# Altium schematic sheet JTAG.SchDoc
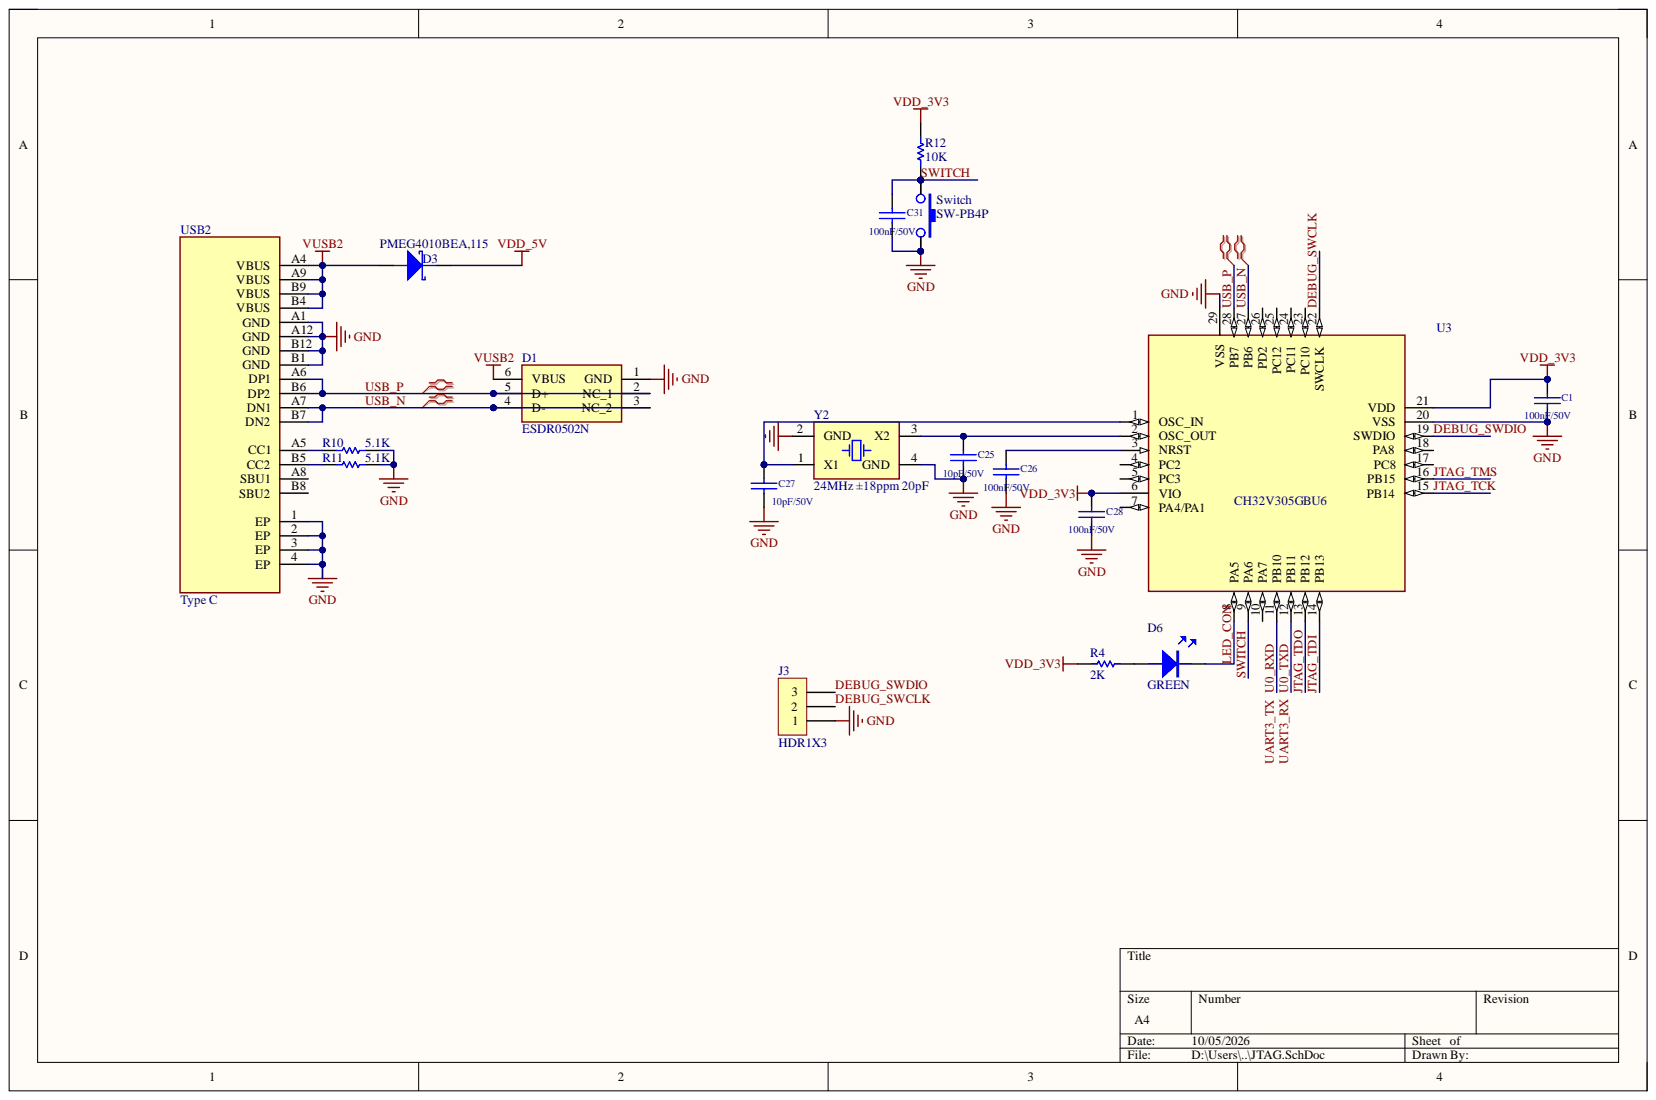
[diagram p0: JTAG.SchDoc]

## Parts
C1  100nF/50V  [C0402]
C25  10pF/50V  [C0402]
C26  100nF/50V  [C0402]
C27  10pF/50V  [C0402]
C28  100nF/50V  [C0402]
C31  100nF/50V  [C0402]
D1  ESDR0502N  [UDFN-6(1x1.2)]
D3  PMEG4010BEA,115  [SOD-323]
D6  GREEN  [LED0402 GREEN]
J3  HDR1X3  [1.27-3PIN]
R4  2K  [R0402]
R10  5.1K  [R0402]
R11  5.1K  [R0402]
R12  10K  [R0402]
Switch  SW-PB4P  Name: Switch  [SMD,3.3x4.2mm]
U3  CH32V305GBU6  [QFN28 4*4]
USB2  Type C  [TYPE-C-16]
Y2  24MHz ±18ppm 20pF  [OSC 2016-4P]

## Nets
GND: C1.2 C25.2 C26.2 C27.2 C28.2 C31.2 D1.1(GND) J3.1 R10.1 R11.1 Switch.3 Switch.4 U3.20(VSS) U3.29(VSS) USB2.1(EP) USB2.2(EP) USB2.3(EP) USB2.4(EP) USB2.A1(GND) USB2.A12(GND) USB2.B1(GND) USB2.B12(GND) Y2.2(GND) Y2.4(GND)
VDD_3V3: C1.1 C28.1 R4.2 R12.1 U3.6(VIO) U3.21(VDD)
VDD_5V: D3.K
VUSB2: D1.6(VBUS) D3.A USB2.A4(VBUS) USB2.A9(VBUS) USB2.B4(VBUS) USB2.B9(VBUS)
DEBUG_SWCLK: J3.2 U3.22(SWCLK)
DEBUG_SWDIO: J3.3 U3.19(SWDIO)
JTAG_TCK: U3.15(PB14)
JTAG_TDI: U3.14(PB13)
JTAG_TDO: U3.13(PB12)
JTAG_TMS: U3.16(PB15)
LED_CON: D6.K U3.8(PA5)
SWITCH: C31.1 R12.2 Switch.1 Switch.2 U3.9(PA6)
U0_RXD: U3.11(PB10)
U0_TXD: U3.12(PB11)
USB_N: D1.3(NC_2) D1.4(D-) U3.27(PB6) USB2.A7(DN1) USB2.B7(DN2)
USB_P: D1.2(NC_1) D1.5(D+) U3.28(PB7) USB2.A6(DP1) USB2.B6(DP2)
NetC25_1: C25.1 U3.2(OSC_OUT) Y2.3(X2)
NetC26_1: C26.1 U3.3(NRST)
NetC27_1: C27.1 U3.1(OSC_IN) Y2.1(X1)
NetD6_A: D6.A R4.1
NetR10_2: R10.2 USB2.A5(CC1)
NetR11_2: R11.2 USB2.B5(CC2)
UART3_RX:
UART3_TX:

Not connected: U3 4(PC2) 5(PC3) 7(PA4/PA1) 10(PA7) 17(PC8) 18(PA8) 23(PC10) 24(PC11) 25(PC12) 26(PD2); USB2 A8(SBU1) B8(SBU2)

## Library data of the parts
C1 C26 C28 C31 = Cap: Capacitor; Manufacturer: SAMSUNG(三星); JCL-Code: C307331; Name: Capacitance; PreferredModel: CL05B104KB54PNC; WeldingType: SMD; Component Kind: Standard; Library Name: My_Integrated_Library.SchLib
C25 C27 = Cap: Capacitor; Manufacturer: muRata(村田); JCL-Code: C161520; Name: Capacitance; PreferredModel: GRM1555C1H100FA01D; WeldingType: SMD; Component Kind: Standard; Library Name: My_Integrated_Library.SchLib
D1 = ESDR0502N: Ultra Low Capacitance ESD Protection Array; Manufacturer: onsemi(安森美); JCL-Code: C94372; Name: TVS Diode Array; PreferredModel: ESDR0502NMUTAG; WeldingType: SMD; Component Kind: Standard; Library Name: My_Integrated_Library.SchLib
D3 = Schottky Diode: Manufacturer: Nexperia; JCL-Code: C36975; WeldingType: SMD; Component Kind: Standard; Description: Schottky Diode; Library Name: My_Integrated_Library.SchLib; Name: Schottky Diode; PreferredModel: PMEG4010BEA,115
D6 = LED: Manufacturer: 国星光电; JCL-Code: C130723; PreferredModel: NCD0402G1; WeldingType: SMD; Component Kind: Standard; Description: LED; Name: LED; Library Name: SCH_LIB_CJH.SchLib
R4 = Res: Resistance; Manufacturer: UNI-ROYAL(厚声); JCL-Code: C4109; PreferredModel: 0402WGF2001TCE; WeldingType: SMD; Component Kind: Standard; Library Name: My_Integrated_Library.SchLib; Name: Resistance
R10 R11 = Res: Resistance; Manufacturer: UNI-ROYAL(厚声); JCL-Code: C25905; PreferredModel: 0402WGF5101TCE; WeldingType: SMD; Component Kind: Standard; Library Name: My_Integrated_Library.SchLib; Name: Resistance
R12 = Res: Resistance; Manufacturer: YAGEO; InternalNumbering: GRD1049; JCL-Code: C144807; PreferredModel: AC0402FR-0710KL; WeldingType: SMD; Component Kind: Standard; Library Name: My_Integrated_Library.SchLib; Name: Resistance
Switch = SW-PB4P: Manufacturer: DEALON(德艺隆); JCL-Code: C2905184; PreferredModel: TD-1221SR-2.5H-250; WeldingType: SMD; Component Kind: Standard; Description: SW-PB4P; Library Name: My_Integrated_Library.SchLib
U3 = CH32V305GBU6: RISC-V MCU; Manufacturer: WCH; WeldingType: SMD; Component Kind: Standard; Library Name: My_Integrated_Library.SchLib; Name: MCU; PreferredModel: CH32V305GBU6
USB2 = Type C: USB Type C; WeldingType: SMD; Component Kind: Standard; Library Name: My_Integrated_Library.SchLib; Name: USB Type C
Y2 = XTAL_4P: Manufacturer: YXC(扬兴晶振); JCL-Code: C22434877; Name: Crystal oscillator; PreferredModel: XL7EL89COI-111YLC-24M; WeldingType: SMD; Component Kind: Standard; Library Name: My_Integrated_Library.SchLib
J3 = HDR1X3: Component Kind: Standard; Description: HDR1X3; Library Name: My_Integrated_Library.SchLib
